# Altium schematic sheet [6]-Interface_CAN.SchDoc
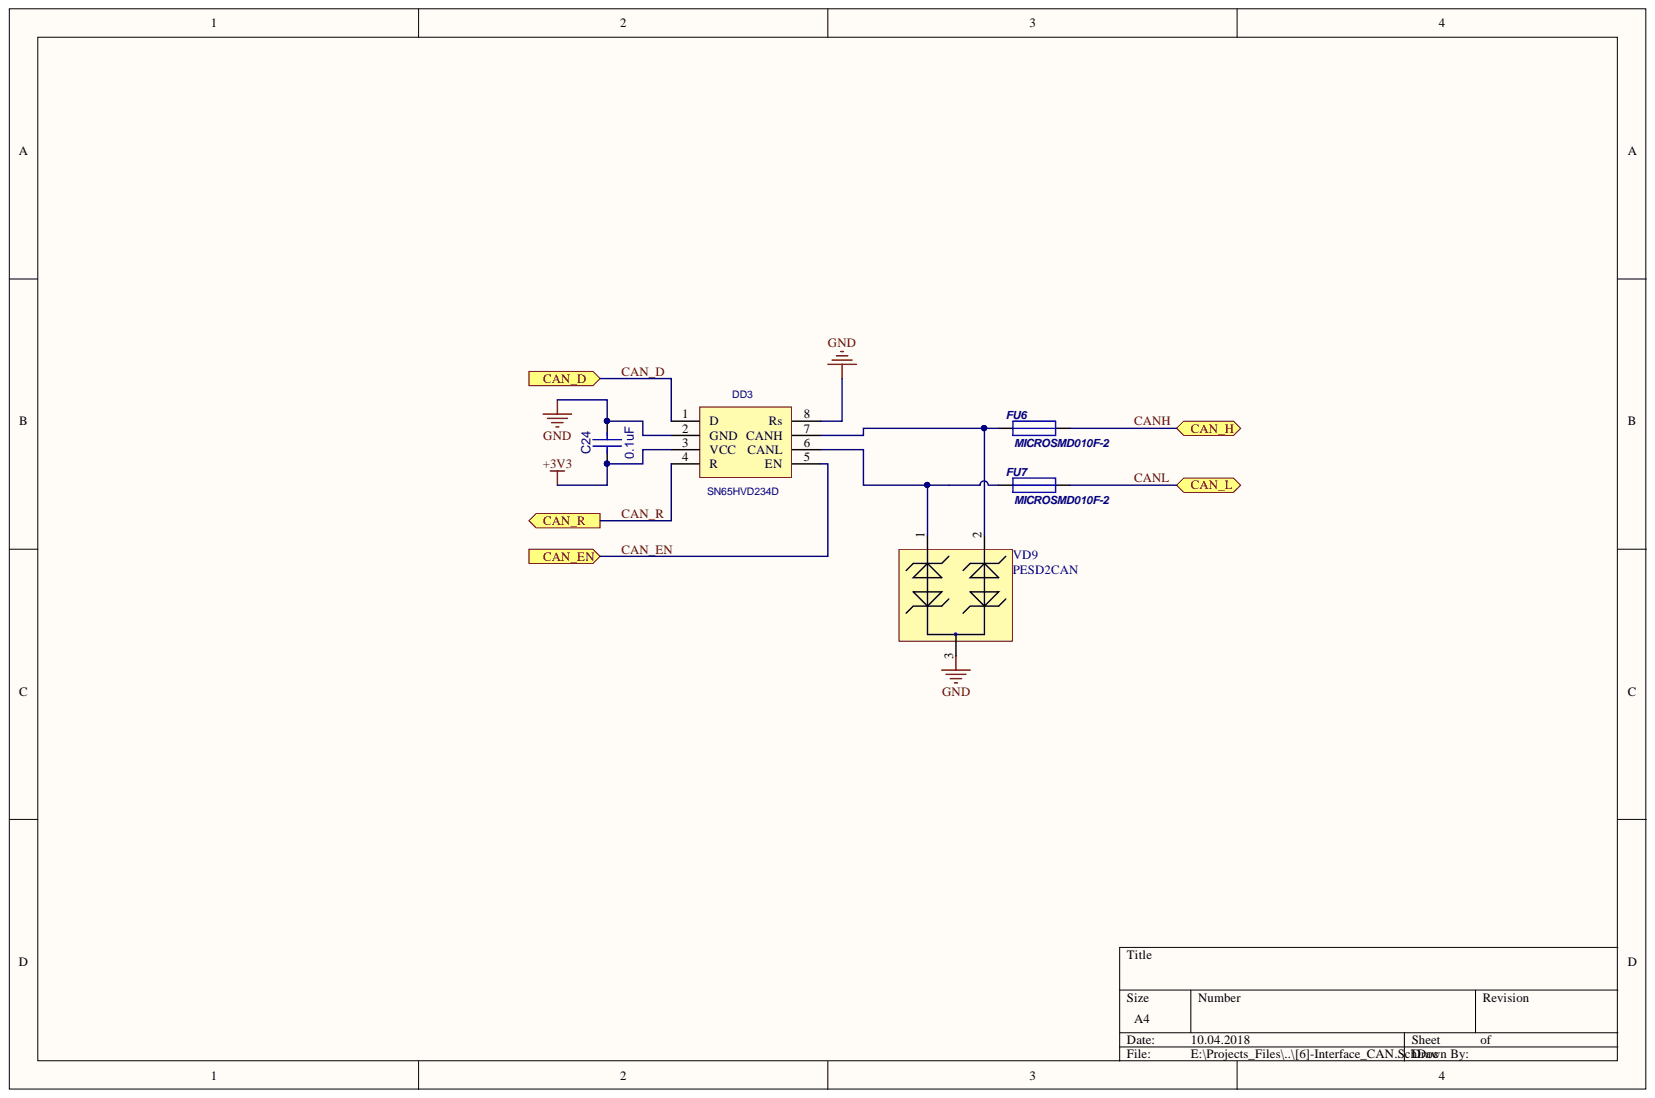
[diagram p0: [6]-Interface_CAN.SchDoc]

## Parts
C24  0.1uF  [CC0603]
DD3  SN65HVD234D  [SO-8]
FU6  MICROSMD010F-2  [RC1210]
FU7  MICROSMD010F-2  [RC1210]
VD9  PESD2CAN  [SOT-23(123)]

## Ports
CAN_D, CAN_EN, CAN_H, CAN_L, CAN_R

## Nets
+3V3: C24.1 DD3.3(VCC)
GND: C24.2 DD3.2(GND) DD3.8(Rs) VD9.3
CAN_D: DD3.1(D) ; port CAN_D
CAN_EN: DD3.5(EN) ; port CAN_EN
CAN_R: DD3.4(R) ; port CAN_R
CANH: FU6.2 ; port CAN_H
CANL: FU7.2 ; port CAN_L
NetDD3_6: DD3.6(CANL) FU7.1 VD9.1
NetDD3_7: DD3.7(CANH) FU6.1 VD9.2

## Library data of the parts
C24 = CC0603: Component Kind: Standard; Description: CC0603; Value: 0.1uF; Library Name: gprs_modem_2.SchLib
DD3 = SN65HVD234D: CAN Transceiver; Component Kind: Standard; Library Name: gprs_modem_2.SchLib
FU6 FU7 = MICROSMD: Component Kind: Standard; Description: MICROSMD; Value: MICROSMD010F-2; Library Name: gprs_modem_2.SchLib
VD9 = PESD2CAN: TVS for CAN; Component Kind: Standard; Library Name: gprs_modem_2.SchLib
